# Altium schematic sheet Power.SchDoc(Power)
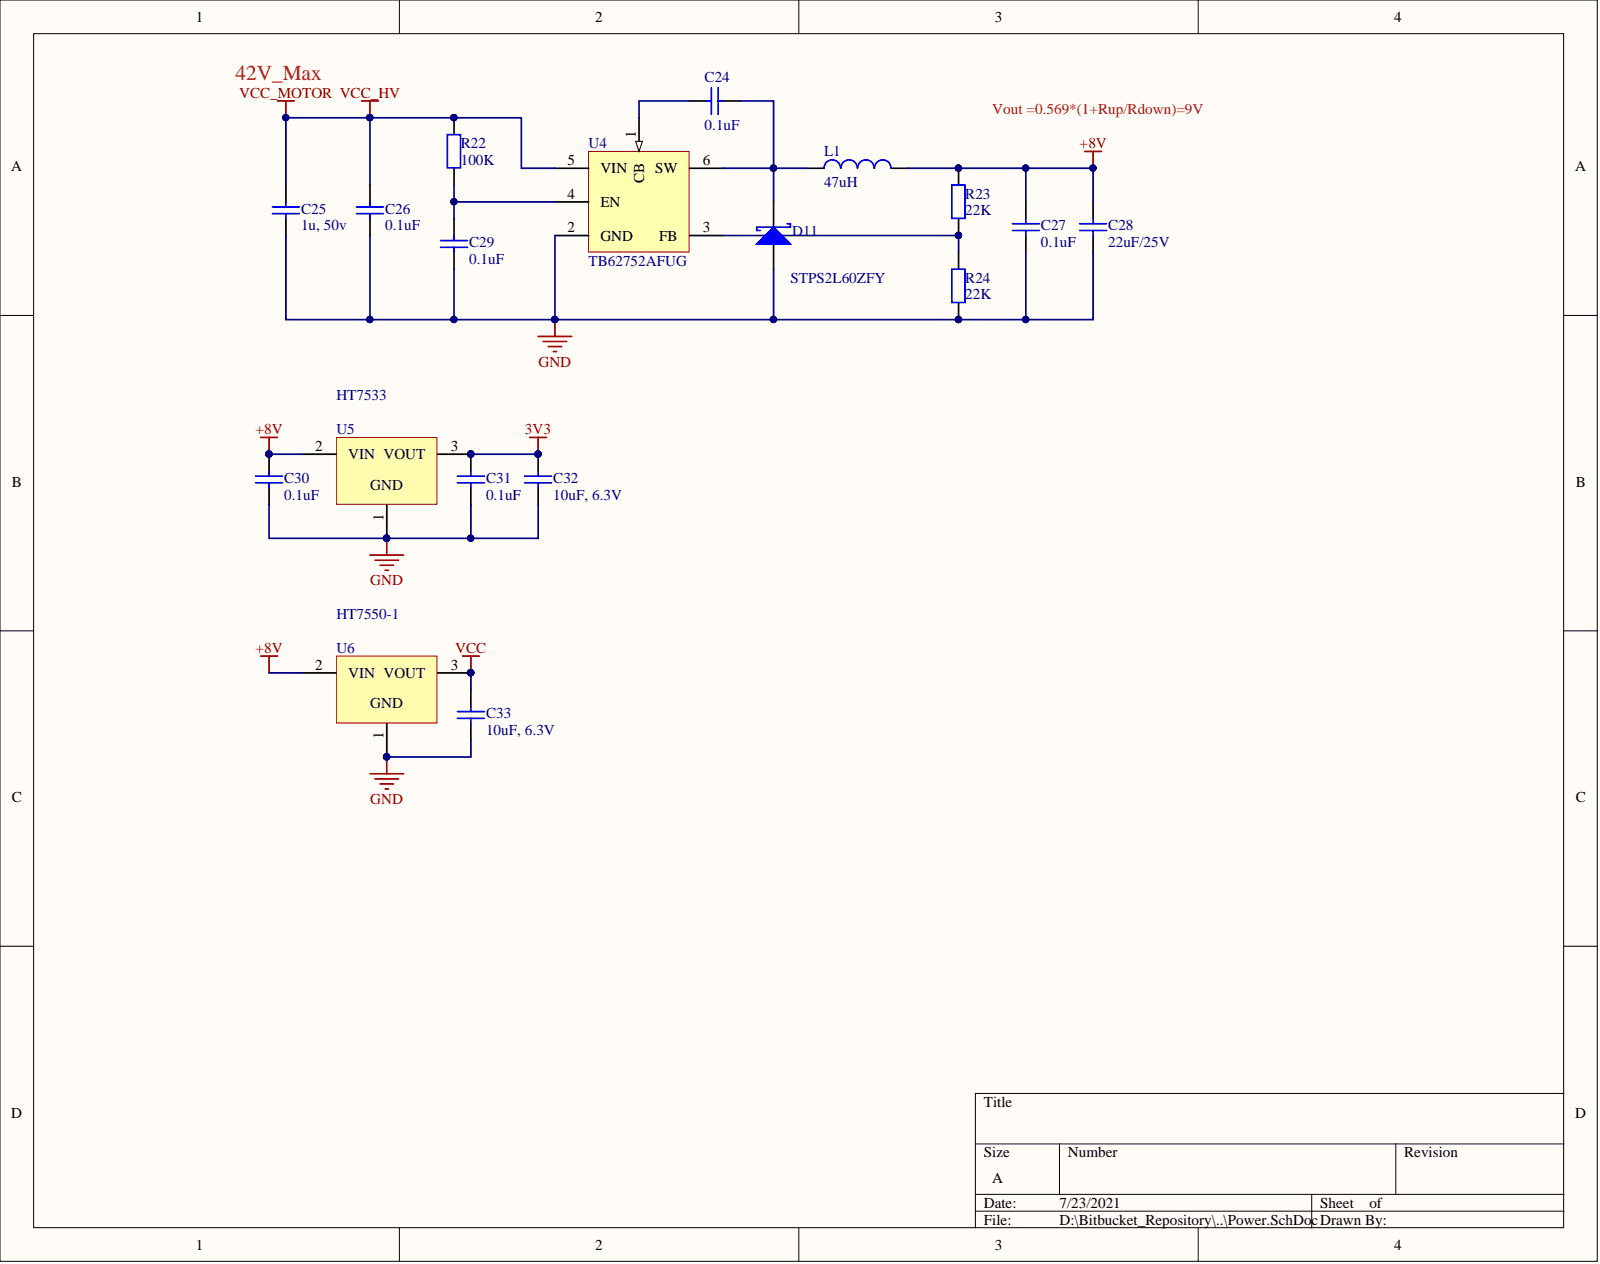
[diagram p0: Power.SchDoc]

## Parts
C24  value 0.1uF  [C0603]  (hidden comment: Ceramic Cap 0603 0.1uF 50V 10% X7R)
C25  value 1u, 50v  [C1206NP]  (hidden comment: Ceramic Cap 1206 1uF 50V 10% X5R)
C26  value 0.1uF  [C0603]  (hidden comment: Ceramic Cap 0603 0.1uF 50V 10% X7R)
C27  value 0.1uF  [C0603]  (hidden comment: Ceramic Cap 0603 0.1uF 50V 10% X7R)
C28  value 22uF/25V  [C1206NP]  (hidden comment: 22uF ±20% 25V X5R 1206 Multilayer Ceramic Capacitors)
C29  value 0.1uF  [C0603]  (hidden comment: Ceramic Cap 0603 0.1uF 50V 10% X7R)
C30  value 0.1uF  [C0603]  (hidden comment: Ceramic Cap 0603 0.1uF 50V 10% X7R)
C31  value 0.1uF  [C0603]  (hidden comment: Ceramic Cap 0603 0.1uF 50V 10% X7R)
C32  value 10uF, 6.3V  [C0603]  (hidden comment: Ceramic Cap 0603 10uF 6.3V 20% X5R)
C33  value 10uF, 6.3V  [C0603]  (hidden comment: Ceramic Cap 0603 10uF 6.3V 20% X5R)
D11  value STPS2L60ZFY  [SOD-123]  (hidden comment: BAT54WS)
L1  47uH  [L4040]
R22  value 100K  [R0603]  (hidden comment: RES 100K 5% 1/10W 0603)
R23  value 22K  [R0603]  (hidden comment: RES 22K 5% 1/10W 0603)
R24  value 22K  [R0603]  (hidden comment: RES 22K 5% 1/10W 0603)
U4  TB62752AFUG  [SOT-23-6]
U5  HT7533  [SOT-89]
U6  HT7550-1  [SOT-89]

## Nets
3V3: C31.2 C32.2 U5.3
+8V: C27.2 C28.1 C30.2 L1.2 R23.1 U5.2 U6.2
GND: C25.2 C26.1 C27.1 C28.2 C29.1 C30.1 C31.1 C32.1 C33.1 D11.1 R24.2 U4.2(GND) U5.1 U6.1
VCC: C33.2 U6.3
VCC_HV: C25.1 C26.2 R22.2 U4.5(VIN)
NetC24_1: C24.1 D11.2 L1.1 U4.6(SW)
NetC24_2: C24.2 U4.1(CB)
NetC29_2: C29.2 R22.1 U4.4(EN)
NetR23_2: R23.2 R24.1 U4.3(FB)

## Library data of the parts
C24 C26 C27 C29-C31 = GRM188R71H104KA93: Murata General Purpose Ceramic Cap 0603 0.1uF 50V 10% X7R; Component Kind: Standard; Library Name: capacitor.SchLib; Signal Integrity: Cap; Simulation: CAP; Supplier 1: Digi-Key; Supplier Part Number 1: 490-1519-1-ND
C25 = C3216X5R1H105K: TDK General Purpose Ceramic Cap 1206 1uF 50V 10% X5R; Component Kind: Standard; Library Name: capacitor.SchLib; Signal Integrity: Cap; Simulation: CAP; Supplier 1: Digi-Key; Supplier Part Number 1: 445-4044-1-ND
C28 = GRM31CR61E226ME15L: 22uF ±20% 25V X5R 1206 Multilayer Ceramic Capacitors MLCC - SMD/SMT RoHS; Component Kind: Standard; Library Name: capacitor.SchLib; Signal Integrity: Cap; Simulation: CAP; Supplier 1: Digi-Key
C32 C33 = GRM188R60J106ME47: Murata General Purpose Ceramic Cap 0603 10uF 6.3V 20% X5R; Component Kind: Standard; Library Name: capacitor.SchLib; Signal Integrity: Cap; Simulation: CAP; Supplier 1: Digi-Key; Supplier Part Number 1: 490-3896-1-ND; Supplier Part Number 2: FL1097
D11 = STPS2L60ZFY: Schottky Diode 60V 2A SOD-123; Component Kind: Standard; Library Name: diode.SchLib; Signal Integrity: D Schottky; Simulation: SKYDIODE; Supplier 1: LCSC; Supplier Part Number 1: C222352
L1 = GCNR4018-470MC: 47uH ±20% 420mA 850mΩ SMD,4x4x1.8mm Inductors; Component Kind: Standard; Library Name: inductor.SchLib; Supplier Part Number 1: C439343; Value: 47uH
R22 = RC0603JR-07100KL: Yageo RES 100K 5% 1/10W 0603; Component Kind: Standard; Library Name: resistor.SchLib; Signal Integrity: Res; Simulation: RESISTOR; Supplier 1: Digi-Key; Supplier Part Number 1: 311-100KGRCT-ND
R23 R24 = RC0603JR-0722KL: Yageo RES 22K 5% 1/10W 0603; Component Kind: Standard; Library Name: resistor.SchLib; Signal Integrity: Res; Simulation: RESISTOR; Supplier 1: Digi-Key; Supplier Part Number 1: 311-22KGRCT-ND
U4 = TB62752AFUG: Toshiba Step Up Type DC/DC Converter for White LED; Component Kind: Standard; Library Name: pmic.SchLib; Supplier 1: Digi-Key; Supplier Part Number 1: TB62752AFUGELCT-ND; Value: TB62752AFUG
U5 = HT7533: 3v3; Value: LM317; Component Kind: Standard; Library Name: pmic.SchLib; Supplier Part Number 2: FL1946
U6 = HT7550_1: 5V; Value: HT7550; Component Kind: Standard; Library Name: pmic.SchLib
